# Altium schematic sheet vertical_counter.SchDoc(vertical_counter)
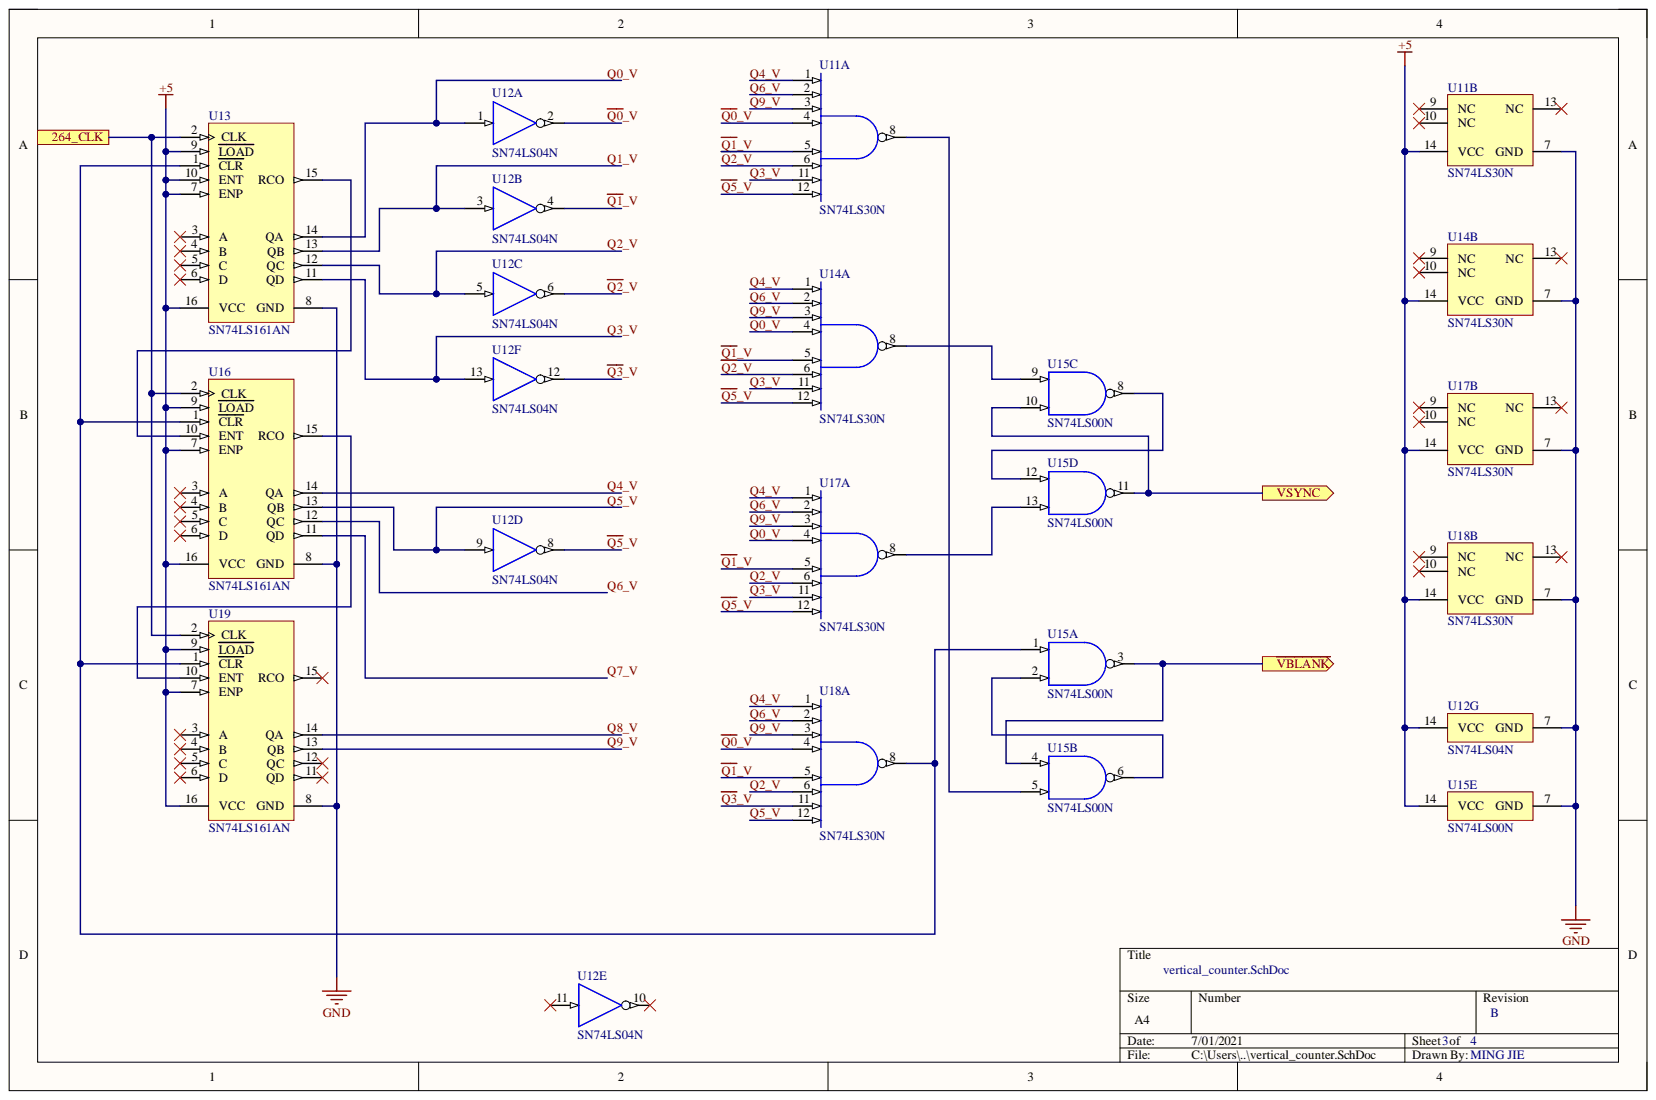
[diagram p0: vertical_counter.SchDoc]

## Parts
U11  SN74LS30N  [N0014A]  (parts A,B)
U12  SN74LS04N  [N0014A]  (parts A,B,C,D,E,F,G)
U13  SN74LS161AN  [N0016A]
U14  SN74LS30N  [N0014A]  (parts A,B)
U15  SN74LS00N  [N0014A]  (parts A,B,C,D,E)
U16  SN74LS161AN  [N0016A]
U17  SN74LS30N  [N0014A]  (parts A,B)
U18  SN74LS30N  [N0014A]  (parts A,B)
U19  SN74LS161AN  [N0016A]

## Ports
264_CLK, VSYNC, ~{VBLANK}

## Nets
+5: U11.14(VCC) U12.14(VCC) U13.7(ENP) U13.9(LOAD) U13.10(ENT) U13.16(VCC) U14.14(VCC) U15.14(VCC) U16.7(ENP) U16.9(LOAD) U16.16(VCC) U17.14(VCC) U18.14(VCC) U19.7(ENP) U19.9(LOAD) U19.16(VCC)
GND: U11.7(GND) U12.7(GND) U13.8(GND) U14.7(GND) U15.7(GND) U16.8(GND) U17.7(GND) U18.7(GND) U19.8(GND)
264_CLK: U13.2(CLK) U16.2(CLK) U19.2(CLK) ; port 264_CLK
Q0_V: U12.1 U13.14(QA) U14.4 U17.4
Q1_V: U12.3 U13.13(QB)
Q2_V: U12.5 U13.12(QC) U17.6 U18.6
Q3_V: U11.11 U12.13 U13.11(QD) U14.11 U17.11
Q4_V: U11.1 U14.1 U16.14(QA) U17.1 U18.1
Q5_V: U12.9 U16.13(QB) U18.12
Q6_V: U11.2 U14.2 U16.12(QC) U17.2 U18.2
Q7_V: U16.11(QD)
Q8_V: U19.14(QA)
Q9_V: U11.3 U14.3 U17.3 U18.3 U19.13(QB)
~{Q0}_V: U11.4 U12.2 U18.4
~{Q1}_V: U11.5 U12.4 U14.5 U17.5 U18.5
~{Q2}_V: U11.6 U12.6 U14.6
~{Q3}_V: U12.12 U18.11
~{Q5}_V: U11.12 U12.8 U14.12 U17.12
~{VBLANK}: U15.3 U15.4 ; port ~{VBLANK}
VSYNC: U15.10 U15.11 ; port VSYNC
NetU11_8: U11.8 U15.5
NetU13_1: U13.1(CLR) U15.1 U16.1(CLR) U18.8 U19.1(CLR)
NetU13_15: U13.15(RCO) U16.10(ENT)
NetU14_8: U14.8 U15.9
NetU15_2: U15.2 U15.6
NetU15_8: U15.8 U15.12
NetU15_13: U15.13 U17.8
NetU16_15: U16.15(RCO) U19.10(ENT)

Not connected: U11 9(NC) 10(NC) 13(NC); U12 10 11; U13 3(A) 4(B) 5(C) 6(D); U14 9(NC) 10(NC) 13(NC); U16 3(A) 4(B) 5(C) 6(D); U17 9(NC) 10(NC) 13(NC); U18 9(NC) 10(NC) 13(NC); U19 3(A) 4(B) 5(C) 6(D) 11(QD) 12(QC) 15(RCO)

## Library data of the parts
U11 U14 U17 U18 = CMP-1627-00459-2: 8-input positive-NAND gates, N0014A, TUBE; Manufacturer: Texas Instruments; Bits(#): 1; F @ Nom Voltage(Max)(Mhz): 35; ICC @ Nom Voltage(Max)(mA): 0.006; Input Type: TTL; Output Drive (IOL/IOH)(Max)(mA): 0.4/-16; Rating: Catalog; Schmitt Trigger: No; Technology Family: LS; tpd @ Nom Voltage(Max)(ns): 22; VCC(Max)(V): 5.25; VCC(Min)(V): 4.75; Voltage(Nom)(V): 5; Code_JEDEC: MS-001; Component Kind: Standard; DatasheetVersion: SDLS099; Generic Part Number: SN74LS30; Library Name: Altium Content Vault; Operating Temperature Range(C): 0 to 70; Output Type: TTL; PackageReference: N0014A
U12 = CMP-1615-00911-2: Hex inverters, N0014A, TUBE; Manufacturer: Texas Instruments; Bits(#): 6; F @ Nom Voltage(Max)(Mhz): 35; ICC @ Nom Voltage(Max)(mA): 0.033; Input Type: TTL; Output Drive (IOL/IOH)(Max)(mA): -0.4/8; Output Type: CMOS; Rating: Catalog; Schmitt Trigger: No; Technology Family: LS; tpd @ Nom Voltage(Max)(ns): 22; VCC(Max)(V): 5.25; VCC(Min)(V): 4.75; Voltage(Nom)(V): 5; Code_JEDEC: MS-001; Component Kind: Standard; DatasheetVersion: SDLS029C; Generic Part Number: SN74LS04; Library Name: Altium Content Vault; Operating Temperature Range(C): 0 to 70; PackageReference: N0014A
U13 U16 U19 = CMP-1623-00512-2: Synchronous 4-Bit Binary Counters, N0016A, TUBE; Manufacturer: Texas Instruments; Bits(#): 4; F @ Nom Voltage(Max)(Mhz): 35; ICC @ Nom Voltage(Max)(mA): 32; Input Type: TTL; Inputs: 1; Output Drive (IOL/IOH)(Max)(mA): 8/-0.4; Output Type: CMOS; Rating: Catalog; Schmitt Trigger: No; Technology Family: LS; VCC(Max)(V): 5.25; VCC(Min)(V): 4.75; Voltage(Nom)(V): 5; Code_JEDEC: MS-001; Component Kind: Standard; DatasheetVersion: SDLS060; Function: Counter; Generic Part Number: SN74LS161A; Library Name: Altium Content Vault; Operating Temperature Range(C): 0 to 70; PackageReference: N0016A; tpd @ Nom Voltage(Max)(ns): 35; Type: Binary
U15 = CMP-1627-00476-2: Quad 2-input positive-NAND gates, N0014A, TUBE; Manufacturer: Texas Instruments; Bits(#): 4; F @ Nom Voltage(Max)(Mhz): 35; ICC @ Nom Voltage(Max)(mA): 0.022; Input Type: TTL; Output Drive (IOL/IOH)(Max)(mA): 0.4/-16; Rating: Catalog; Schmitt Trigger: No; Technology Family: LS; tpd @ Nom Voltage(Max)(ns): 22; VCC(Max)(V): 5.25; VCC(Min)(V): 4.75; Voltage(Nom)(V): 5; Code_JEDEC: MS-001; Component Kind: Standard; DatasheetVersion: SDLS025B; Generic Part Number: SN74LS00; Library Name: Altium Content Vault; Operating Temperature Range(C): 0 to 70; Output Type: TTL; PackageReference: N0014A
